# Altium schematic sheet 10 - DEVICE POWER.SchDoc("10 - DEVICE POWER")
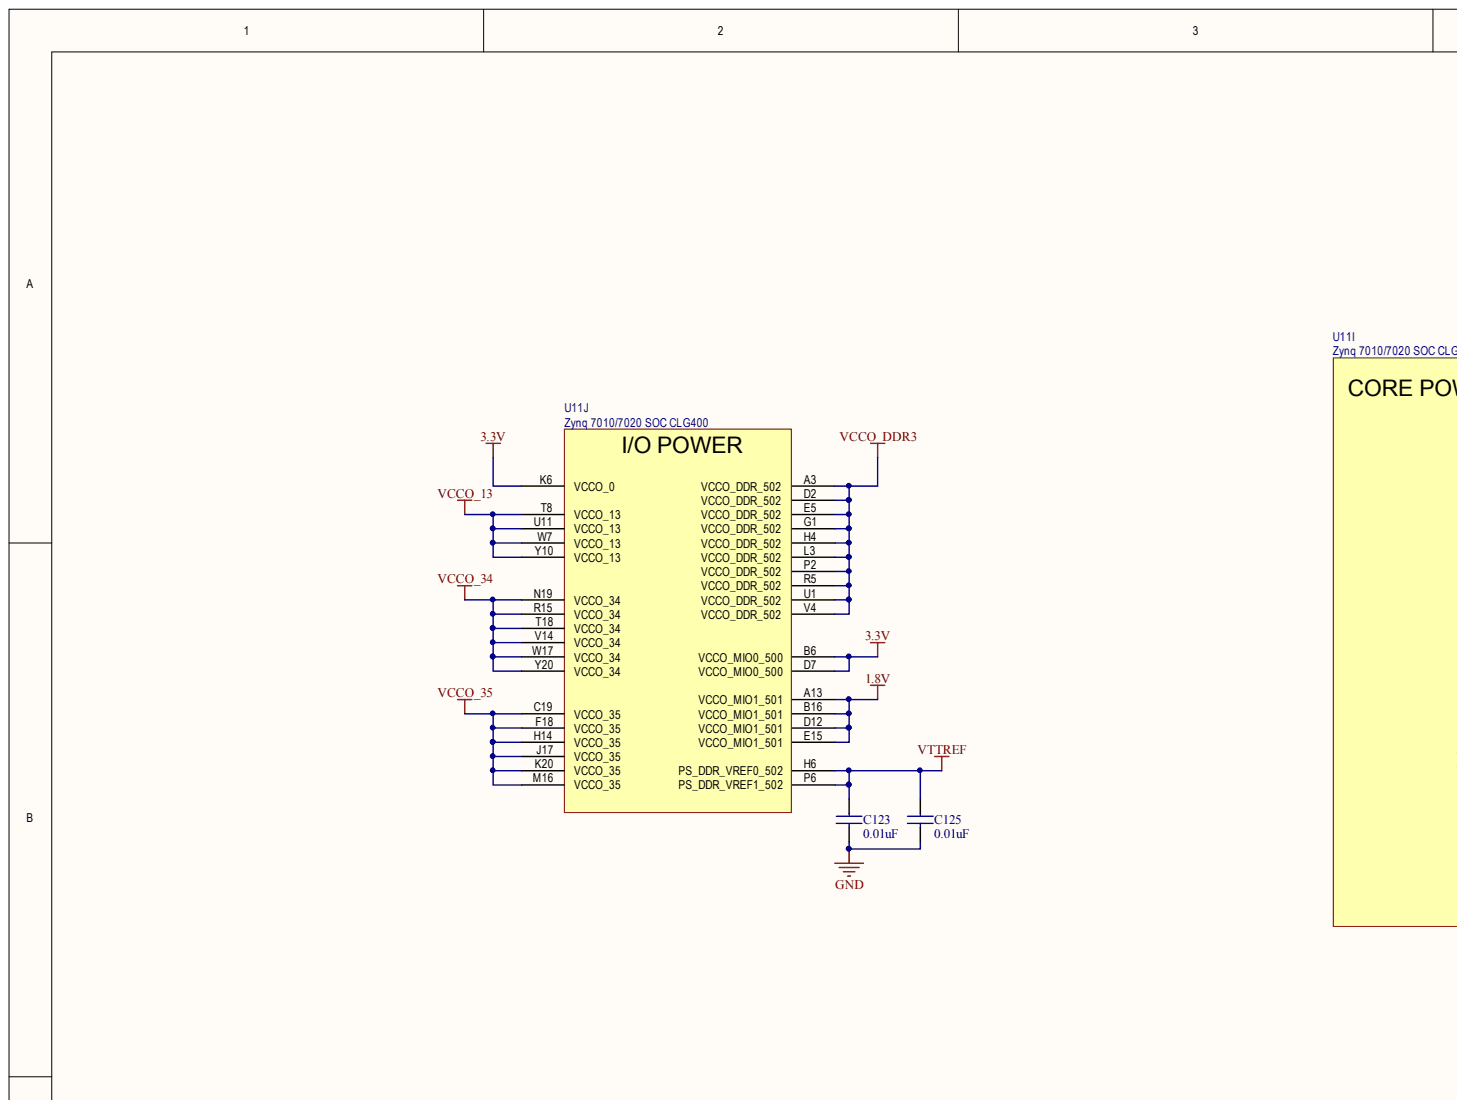
[diagram p0: 10 - DEVICE POWER.SchDoc, top left]
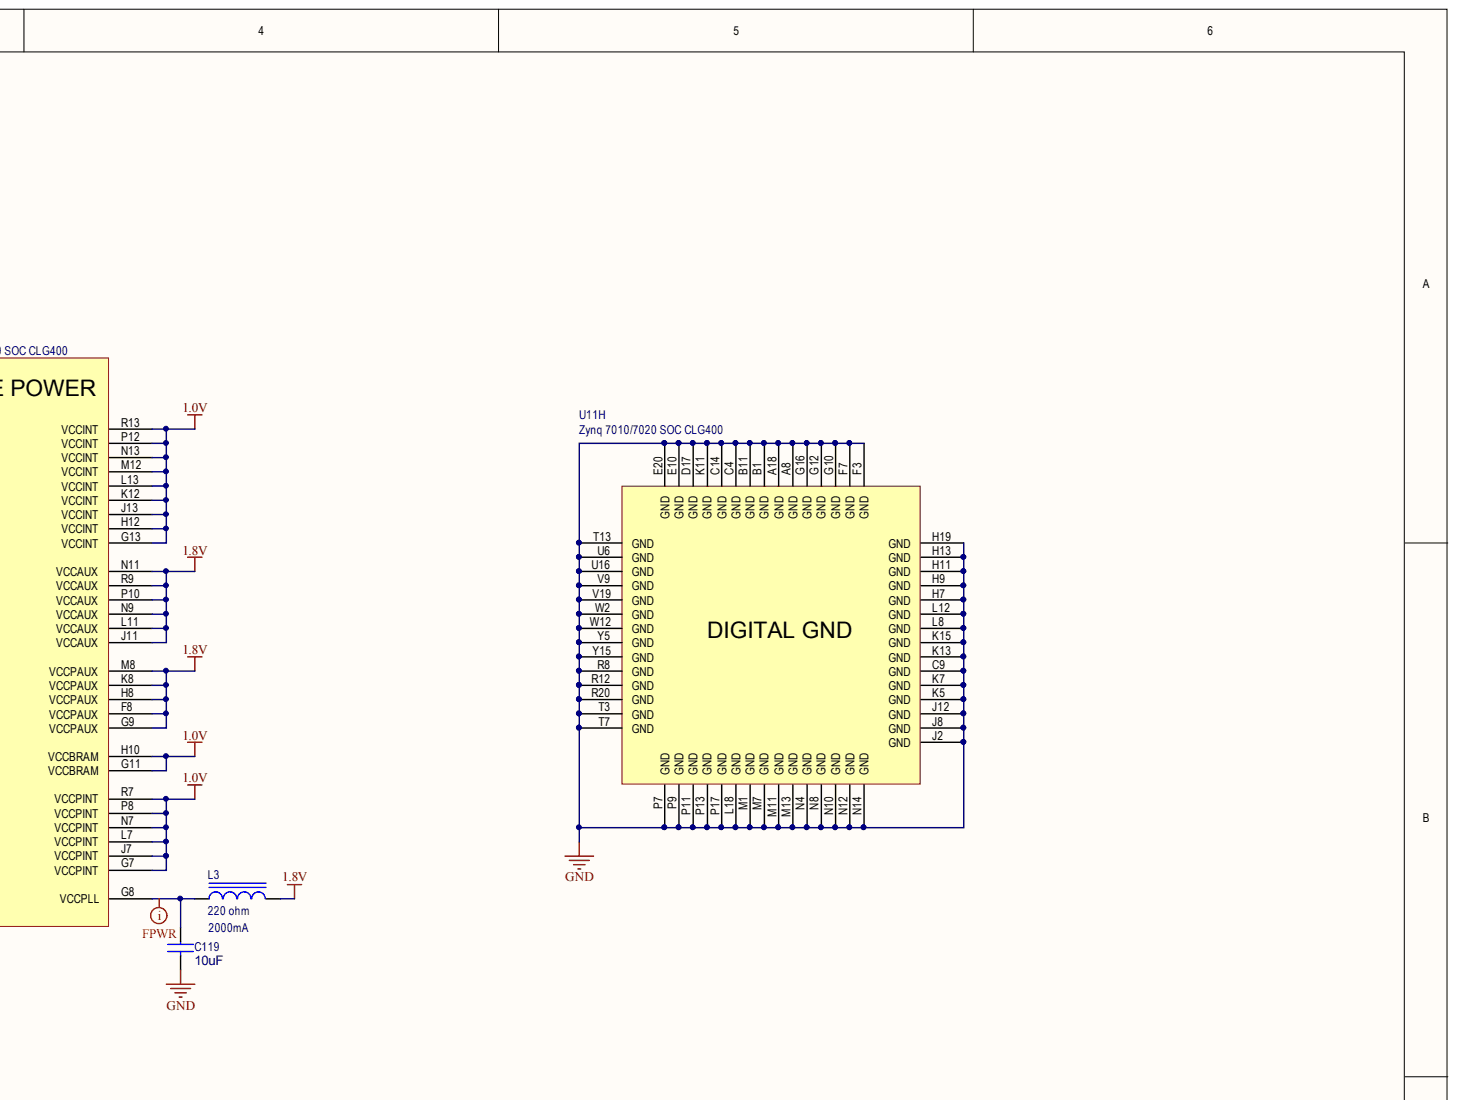
[diagram p1: 10 - DEVICE POWER.SchDoc, top right]
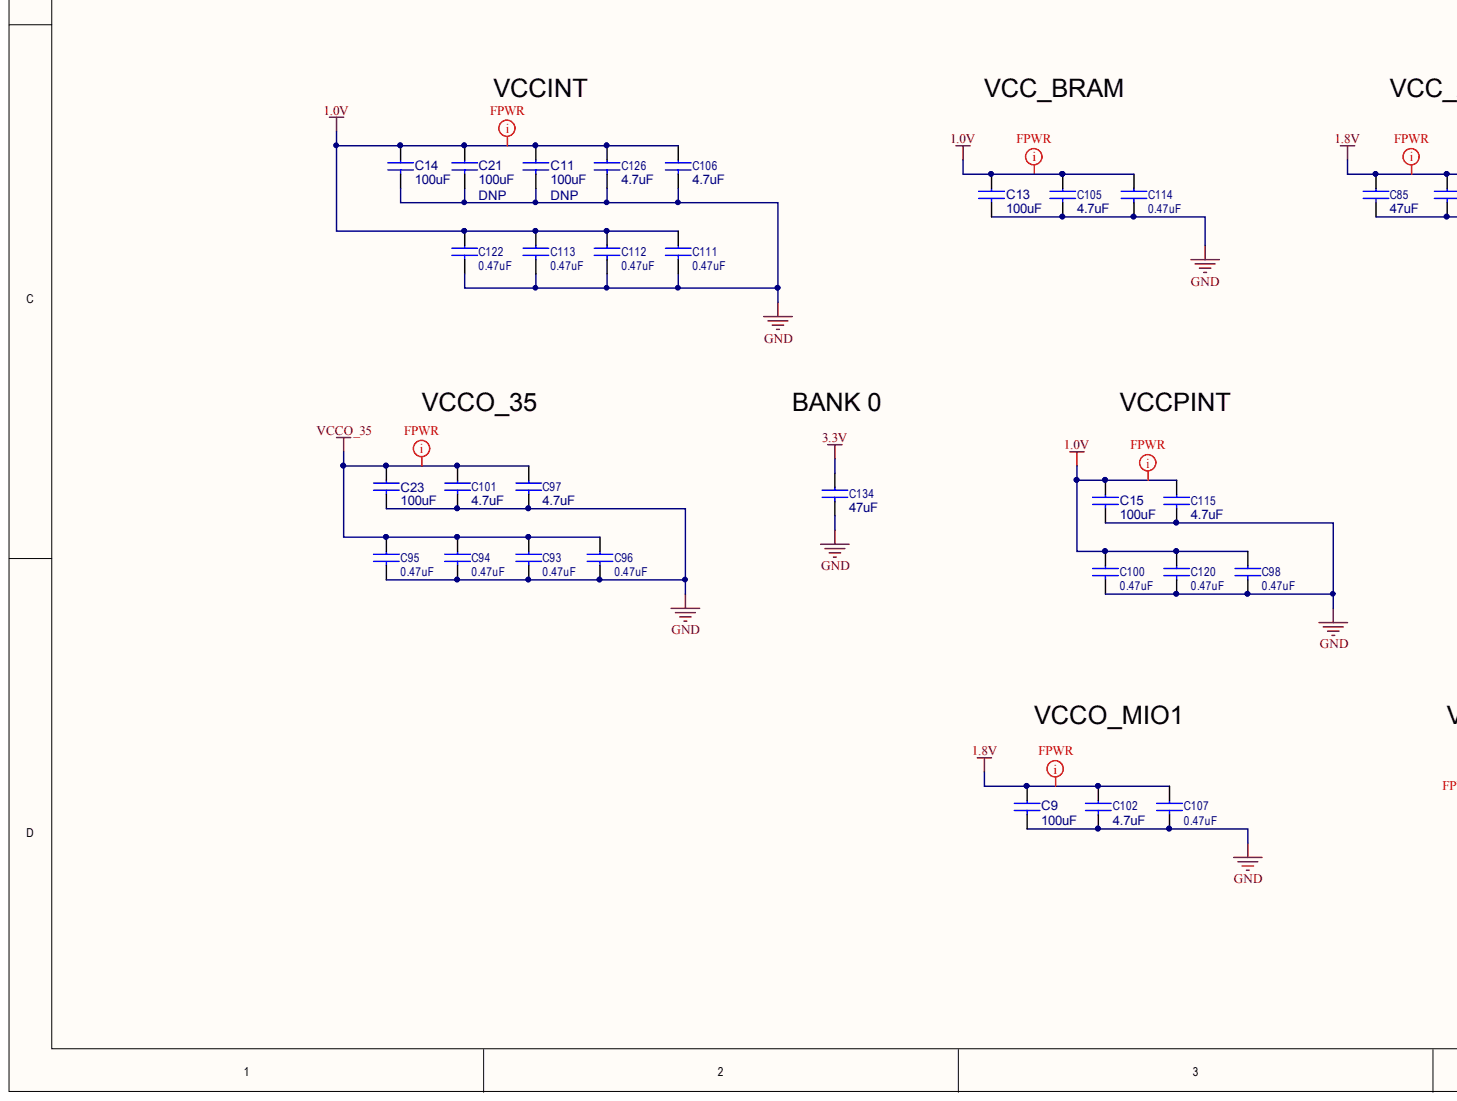
[diagram p2: 10 - DEVICE POWER.SchDoc, bottom left]
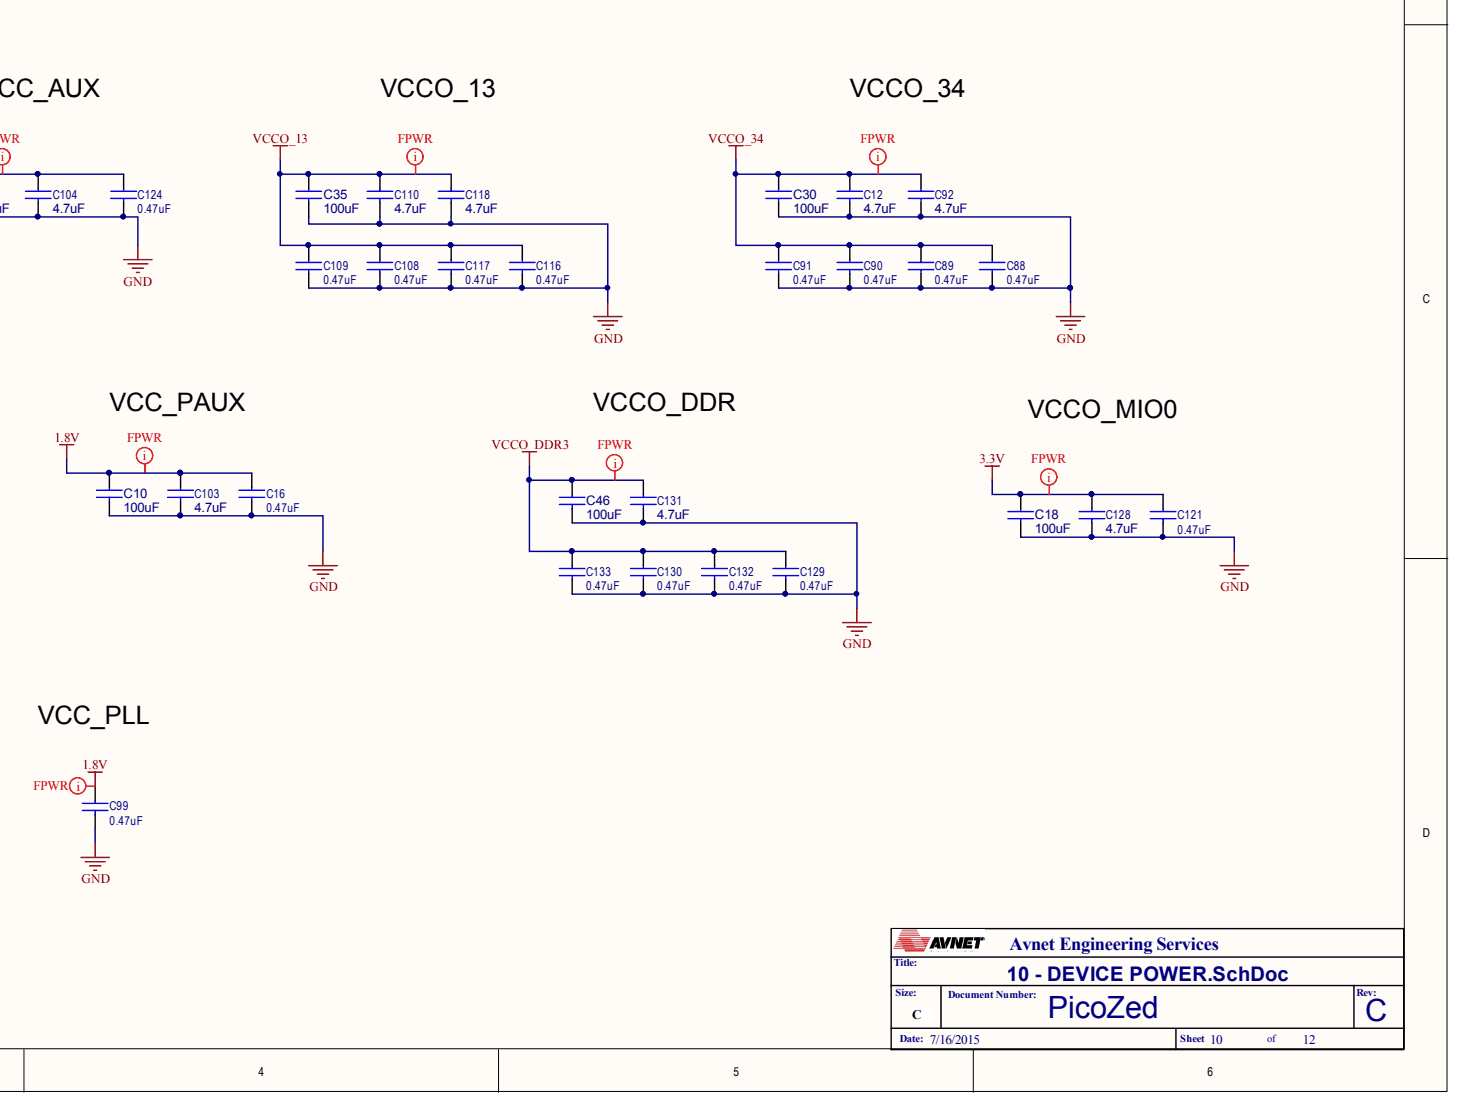
[diagram p3: 10 - DEVICE POWER.SchDoc, bottom right]

## Parts
C9  100uF  [C1210]
C10  100uF  [C1210]
C11  100uF  [C1210]
C12  4.7uF  [C0402]
C13  100uF  [C1210]
C14  100uF  [C1210]
C15  100uF  [C1210]
C16  value 0.47uF  [C0402]  (hidden comment: PC475)
C18  100uF  [C1210]
C21  100uF  [C1210]
C23  100uF  [C1210]
C30  100uF  [C1210]
C35  100uF  [C1210]
C46  100uF  [C1210]
C85  47uF  [C0805]
C88  value 0.47uF  [C0402]  (hidden comment: PC475)
C89  value 0.47uF  [C0402]  (hidden comment: PC475)
C90  value 0.47uF  [C0402]  (hidden comment: PC475)
C91  value 0.47uF  [C0402]  (hidden comment: PC475)
C92  4.7uF  [C0402]
C93  value 0.47uF  [C0402]  (hidden comment: PC475)
C94  value 0.47uF  [C0402]  (hidden comment: PC475)
C95  value 0.47uF  [C0402]  (hidden comment: PC475)
C96  value 0.47uF  [C0402]  (hidden comment: PC475)
C97  4.7uF  [C0402]
C98  value 0.47uF  [C0402]  (hidden comment: PC475)
C99  value 0.47uF  [C0402]  (hidden comment: PC475)
C100  value 0.47uF  [C0402]  (hidden comment: PC475)
C101  4.7uF  [C0402]
C102  4.7uF  [C0402]
C103  4.7uF  [C0402]
C104  4.7uF  [C0402]
C105  4.7uF  [C0402]
C106  4.7uF  [C0402]
C107  value 0.47uF  [C0402]  (hidden comment: PC475)
C108  value 0.47uF  [C0402]  (hidden comment: PC475)
C109  value 0.47uF  [C0402]  (hidden comment: PC475)
C110  4.7uF  [C0402]
C111  value 0.47uF  [C0402]  (hidden comment: PC475)
C112  value 0.47uF  [C0402]  (hidden comment: PC475)
C113  value 0.47uF  [C0402]  (hidden comment: PC475)
C114  value 0.47uF  [C0402]  (hidden comment: PC475)
C115  4.7uF  [C0402]
C116  value 0.47uF  [C0402]  (hidden comment: PC475)
C117  value 0.47uF  [C0402]  (hidden comment: PC475)
C118  4.7uF  [C0402]
C119  10uF  [C0603]
C120  value 0.47uF  [C0402]  (hidden comment: PC475)
C121  value 0.47uF  [C0402]  (hidden comment: PC475)
C122  value 0.47uF  [C0402]  (hidden comment: PC475)
C123  value 0.01uF  [C0201]  (hidden comment: PC003)
C124  value 0.47uF  [C0402]  (hidden comment: PC475)
C125  value 0.01uF  [C0201]  (hidden comment: PC003)
C126  4.7uF  [C0402]
C128  4.7uF  [C0402]
C129  value 0.47uF  [C0402]  (hidden comment: PC475)
C130  value 0.47uF  [C0402]  (hidden comment: PC475)
C131  4.7uF  [C0402]
C132  value 0.47uF  [C0402]  (hidden comment: PC475)
C133  value 0.47uF  [C0402]  (hidden comment: PC475)
C134  47uF  [C0805]
L3  value 220 ohm  [L0805]  (hidden comment: PF009)
U11  Zynq 7010/7020 SOC CLG400  [BGA400C80P20X20_1700X1700X160]  (parts H,I,J)

## Nets
1.0V: C11.1 C13.1 C14.1 C15.1 C21.1 C98.1 C100.1 C105.1 C106.1 C111.1 C112.1 C113.1 C114.1 C115.1 C120.1 C122.1 C126.1 U11.G7(VCCPINT) U11.G11(VCCBRAM) U11.G13(VCCINT) U11.H10(VCCBRAM) U11.H12(VCCINT) U11.J7(VCCPINT) U11.J13(VCCINT) U11.K12(VCCINT) U11.L7(VCCPINT) U11.L13(VCCINT) U11.M12(VCCINT) U11.N7(VCCPINT) U11.N13(VCCINT) U11.P8(VCCPINT) U11.P12(VCCINT) U11.R7(VCCPINT) U11.R13(VCCINT)
1.8V: C9.1 C10.1 C16.1 C85.1 C99.1 C102.1 C103.1 C104.1 C107.1 C124.1 L3.2 U11.A13(VCCO_MIO1_501) U11.B16(VCCO_MIO1_501) U11.D12(VCCO_MIO1_501) U11.E15(VCCO_MIO1_501) U11.F8(VCCPAUX) U11.G9(VCCPAUX) U11.H8(VCCPAUX) U11.J11(VCCAUX) U11.K8(VCCPAUX) U11.L11(VCCAUX) U11.M8(VCCPAUX) U11.N9(VCCAUX) U11.N11(VCCAUX) U11.P10(VCCAUX) U11.R9(VCCAUX)
3.3V: C18.1 C121.1 C128.1 C134.1 U11.B6(VCCO_MIO0_500) U11.D7(VCCO_MIO0_500) U11.K6(VCCO_0)
GND: C9.2 C10.2 C11.2 C12.2 C13.2 C14.2 C15.2 C16.2 C18.2 C21.2 C23.2 C30.2 C35.2 C46.2 C85.2 C88.2 C89.2 C90.2 C91.2 C92.2 C93.2 C94.2 C95.2 C96.2 C97.2 C98.2 C99.2 C100.2 C101.2 C102.2 C103.2 C104.2 C105.2 C106.2 C107.2 C108.2 C109.2 C110.2 C111.2 C112.2 C113.2 C114.2 C115.2 C116.2 C117.2 C118.2 C119.2 C120.2 C121.2 C122.2 C123.2 C124.2 C125.2 C126.2 C128.2 C129.2 C130.2 C131.2 C132.2 C133.2 C134.2 U11.A8(GND) U11.A18(GND) U11.B1(GND) U11.B11(GND) U11.C4(GND) U11.C9(GND) U11.C14(GND) U11.D17(GND) U11.E10(GND) U11.E20(GND) U11.F3(GND) U11.F7(GND) U11.G10(GND) U11.G12(GND) U11.G16(GND) U11.H7(GND) U11.H9(GND) U11.H11(GND) U11.H13(GND) U11.H19(GND) U11.J2(GND) U11.J8(GND) U11.J12(GND) U11.K5(GND) U11.K7(GND) U11.K11(GND) U11.K13(GND) U11.K15(GND) U11.L8(GND) U11.L12(GND) U11.L18(GND) U11.M1(GND) U11.M7(GND) U11.M11(GND) U11.M13(GND) U11.N4(GND) U11.N8(GND) U11.N10(GND) U11.N12(GND) U11.N14(GND) U11.P7(GND) U11.P9(GND) U11.P11(GND) U11.P13(GND) U11.P17(GND) U11.R8(GND) U11.R12(GND) U11.R20(GND) U11.T3(GND) U11.T7(GND) U11.T13(GND) U11.U6(GND) U11.U16(GND) U11.V9(GND) U11.V19(GND) U11.W2(GND) U11.W12(GND) U11.Y5(GND) U11.Y15(GND)
VCCO_13: C35.1 C108.1 C109.1 C110.1 C116.1 C117.1 C118.1 U11.T8(VCCO_13) U11.U11(VCCO_13) U11.W7(VCCO_13) U11.Y10(VCCO_13)
VCCO_34: C12.1 C30.1 C88.1 C89.1 C90.1 C91.1 C92.1 U11.N19(VCCO_34) U11.R15(VCCO_34) U11.T18(VCCO_34) U11.V14(VCCO_34) U11.W17(VCCO_34) U11.Y20(VCCO_34)
VCCO_35: C23.1 C93.1 C94.1 C95.1 C96.1 C97.1 C101.1 U11.C19(VCCO_35) U11.F18(VCCO_35) U11.H14(VCCO_35) U11.J17(VCCO_35) U11.K20(VCCO_35) U11.M16(VCCO_35)
VCCO_DDR3: C46.1 C129.1 C130.1 C131.1 C132.1 C133.1 U11.A3(VCCO_DDR_502) U11.D2(VCCO_DDR_502) U11.E5(VCCO_DDR_502) U11.G1(VCCO_DDR_502) U11.H4(VCCO_DDR_502) U11.L3(VCCO_DDR_502) U11.P2(VCCO_DDR_502) U11.R5(VCCO_DDR_502) U11.U1(VCCO_DDR_502) U11.V4(VCCO_DDR_502)
VTTREF: C123.1 C125.1 U11.H6(PS_DDR_VREF0_502) U11.P6(PS_DDR_VREF1_502)
NetC119_1: C119.1 L3.1 U11.G8(VCCPLL)

## Library data of the parts
C9-C11 C13-C15 C18 C21 C23 C30 C35 C46 = AVPC-0000209: CAP SM 100uF 6.3V 20% X5R C1210; PartCode: PC; AsyTxt: PC209; Database Table Name: Passive-Cap$; MFG Code: MUR; MFGPN: GRM32EF50J107ZE20K; Power: 0; Availability: 180K-AV; AVLNo: AVPC-0000209; CLASSNAME: DDR_TERM; Component Kind: Standard; ComponentLink1Description: Datasheet; Cost: .41; Device: CAP; Dielectric: X5R; PkgType: SM; Received: 0; RoHS: Yes; Size: 9.11; Status: Preliminary; Tol: 20%; Value: 100uF; Volt: 6.3V; ComponentLink1URL: C:\AVDBLIB\DATASHEETS\GRM31CR60J107ME39.pdf; Library Name: Avnet_DBlib.DbLib
  C11 C21: DNP: DNP
C12 C92 C97 C101-C106 C110 C115 C118 C126 C131 = AVPC-0000499: CAP SM 4.7uF 6.3V 20% X5R C0402; PartCode: PC; AsyTxt: PC499; Database Table Name: Passive-Cap$; MFG Code: AVX; MFGPN: GRM155R60J475ME87D; Power: 0; Availability: 140K-DK; AVLNo: AVPC-0000499; CLASSNAME: U1_CAPS; Component Kind: Standard; ComponentLink1Description: Datasheet; Cost: .46; Device: CAP; Dielectric: X5R; PkgType: SM; Received: 0; RoHS: Yes; Size: 1.9; Status: Preliminary; Tol: 20%; Value: 4.7uF; Volt: 6.3V; ComponentLink1URL: C:\AVDBLIB\DATASHEETS\murata_caps_c02e.pdf; Library Name: Avnet_DBlib.DbLib
C16 C88-C91 C93-C96 C98-C100 C107-C109 C111-C114 C116 C117 C120 C121 C124 C129 C130 C132 C133 = AVPC-0000475: CAP SM 0.47uF 6.3V 10% X5R C0402; PartCode: PC; AVLNo: AVPC-0000529; Database Table Name: Passive-Cap$; MFG Code: TAI; MFGPN: JMK105BJ474KV-F/BKN; AsyTxt: PC475; CLASSNAME: U1_CAPS; Component Kind: Standard; ComponentLink1Description: Datasheet; ComponentLink2Description: MFR Website; Device: CAP; Dielectric: X5R; ESR: CAP; PkgType: SM; RoHS: Yes; Status: Preliminary; Tol: 10%; Volt: 6.3V; ComponentLink1URL: C:\AVDBLIB\DATASHEETS\; Library Name: Avnet_DBlib.DbLib
C85 C134 = AVPC-0000521: CAP SM 47uF 6.3V 20% X5R C0805; PartCode: PC; AsyTxt: PC521; Database Table Name: Passive-Cap$; MFG Code: TYC; MFGPN: JMK212BJ476MG-T; Power: 0; Availability: 100K-AV; AVLNo: AVPC-0000521; CLASSNAME: U1_CAPS; Component Kind: Standard; ComponentLink1Description: Datasheet; Cost: .18; Device: CAP; Dielectric: X5R; PkgType: SM; Received: 0; RoHS: Yes; Size: 5.42; Status: Preliminary; Tol: 20%; Value: 47uF; Volt: 6.3V; ComponentLink1URL: C:\AVDBLIB\DATASHEETS\TYC_Caps.pdf; Library Name: Avnet_DBlib.DbLib
C119 = AVPC-0000086: CAP SM 10uF 6.3V 20% X5R C0603; PartCode: PC; AsyTxt: PC086; Database Table Name: Passive-Cap$; MFG Code: AVX; MFGPN: 06036D106MAT2A; AVLNo: AVPC-0000086; CLASSNAME: U1_CAPS; Component Kind: Standard; ComponentLink1Description: Datasheet; Device: CAP; Dielectric: X5R; ESR: CAP; PkgType: SM; RoHS: Yes; Status: Preliminary; Tol: 20%; Value: 10uF; Volt: 6.3V; ComponentLink1URL: C:\AVDBLIB\DATASHEETS\AVX_CCOG.pdf; Library Name: Avnet_DBlib.DbLib
C122 = AVPC-0000475: CAP SM 0.47uF 6.3V 10% X5R C0402; PartCode: PC; AVLNo: AVPC-0000529; CLASSNAMECLASSNAME: U1_CAPS; Database Table Name: Passive-Cap$; MFG Code: TAI; MFGPN: JMK105BJ474KV-F/BKN; AsyTxt: PC475; CLASSNAME: U1_CAPS; Component Kind: Standard; ComponentLink1Description: Datasheet; ComponentLink2Description: MFR Website; Device: CAP; Dielectric: X5R; ESR: CAP; PkgType: SM; RoHS: Yes; Status: Preliminary; Tol: 10%; Volt: 6.3V; ComponentLink1URL: C:\AVDBLIB\DATASHEETS\; Library Name: Avnet_DBlib.DbLib
C123 C125 = AVPC-0000003: CAP SM 0.01uF 10V 10% X5R C0201; PartCode: PC; Database Table Name: Passive-Cap$; MFG Code: AVX; MFGPN: 0201ZD103KAT2A; AsyTxt: PC003; AVLNo: AVPC-0000003; Component Kind: Standard; ComponentLink1Description: Datasheet; ComponentLink2Description: MFR Website; ComponentLink2URL: www.avx.com; Device: CAP; Dielectric: X5R; ESR: CAP; PkgType: SM; RoHS: Yes; Status: Preliminary; Tol: 10%; Volt: 10V; ComponentLink1URL: C:\AVDBLIB\DATASHEETS\AVX_CX5R.pdf; Library Name: Avnet_DBlib.DbLib
C128 = AVPC-0000499: CAP SM 4.7uF 6.3V 20% X5R C0402; PartCode: PC; AsyTxt: PC499; CLASSNAMECLASSNAMECLASSNAME: U1_CAPS; Database Table Name: Passive-Cap$; MFG Code: AVX; MFGPN: GRM155R60J475ME87D; Power: 0; Availability: 140K-DK; AVLNo: AVPC-0000499; CLASSNAME: U1_CAPS; Component Kind: Standard; ComponentLink1Description: Datasheet; Cost: .46; Device: CAP; Dielectric: X5R; PkgType: SM; Received: 0; RoHS: Yes; Size: 1.9; Status: Preliminary; Tol: 20%; Value: 4.7uF; Volt: 6.3V; ComponentLink1URL: C:\AVDBLIB\DATASHEETS\murata_caps_c02e.pdf; Library Name: Avnet_DBlib.DbLib
L3 = AVPF-0000009: FB SM 220 ohm@100MHz 2000mA 0.25 0.045 ohm@DC L0805; PartCode: PF; Database Table Name: Passive-Ferrite Bead$; MFG Code: MUR; MFGPN: BLM21PG221SN1D; Test Freq: 100MHz; Tol: 0.25; AsyTxt: PF009; AVLNo: AVPF-0000009; CLASSNAME: U1_CAPS; Component Kind: Standard; ComponentLink1Description: Datasheet; DCR: 0.045 ohm; Device: FB; Max I: 2000mA; PkgType: SM; RoHS: Yes; Status: Preliminary; ComponentLink1URL: C:\AVDBLIB\DATASHEETS\L0110S0100BLM21P.pdf; Library Name: Avnet_DBlib.DbLib
U11 = Zynq Z010/Z020 SOC CLG400: MFG Code: XLX; MFGPN: XC7Z010-1CLG400C; CLASSNAME: BGA; Component Kind: Standard; Description: Zynq 7010/7020 SOC CLG400; Library Name: Zynq_Z010_ZedStick.SchLib
